# Altium schematic sheet （8）Power.Sch
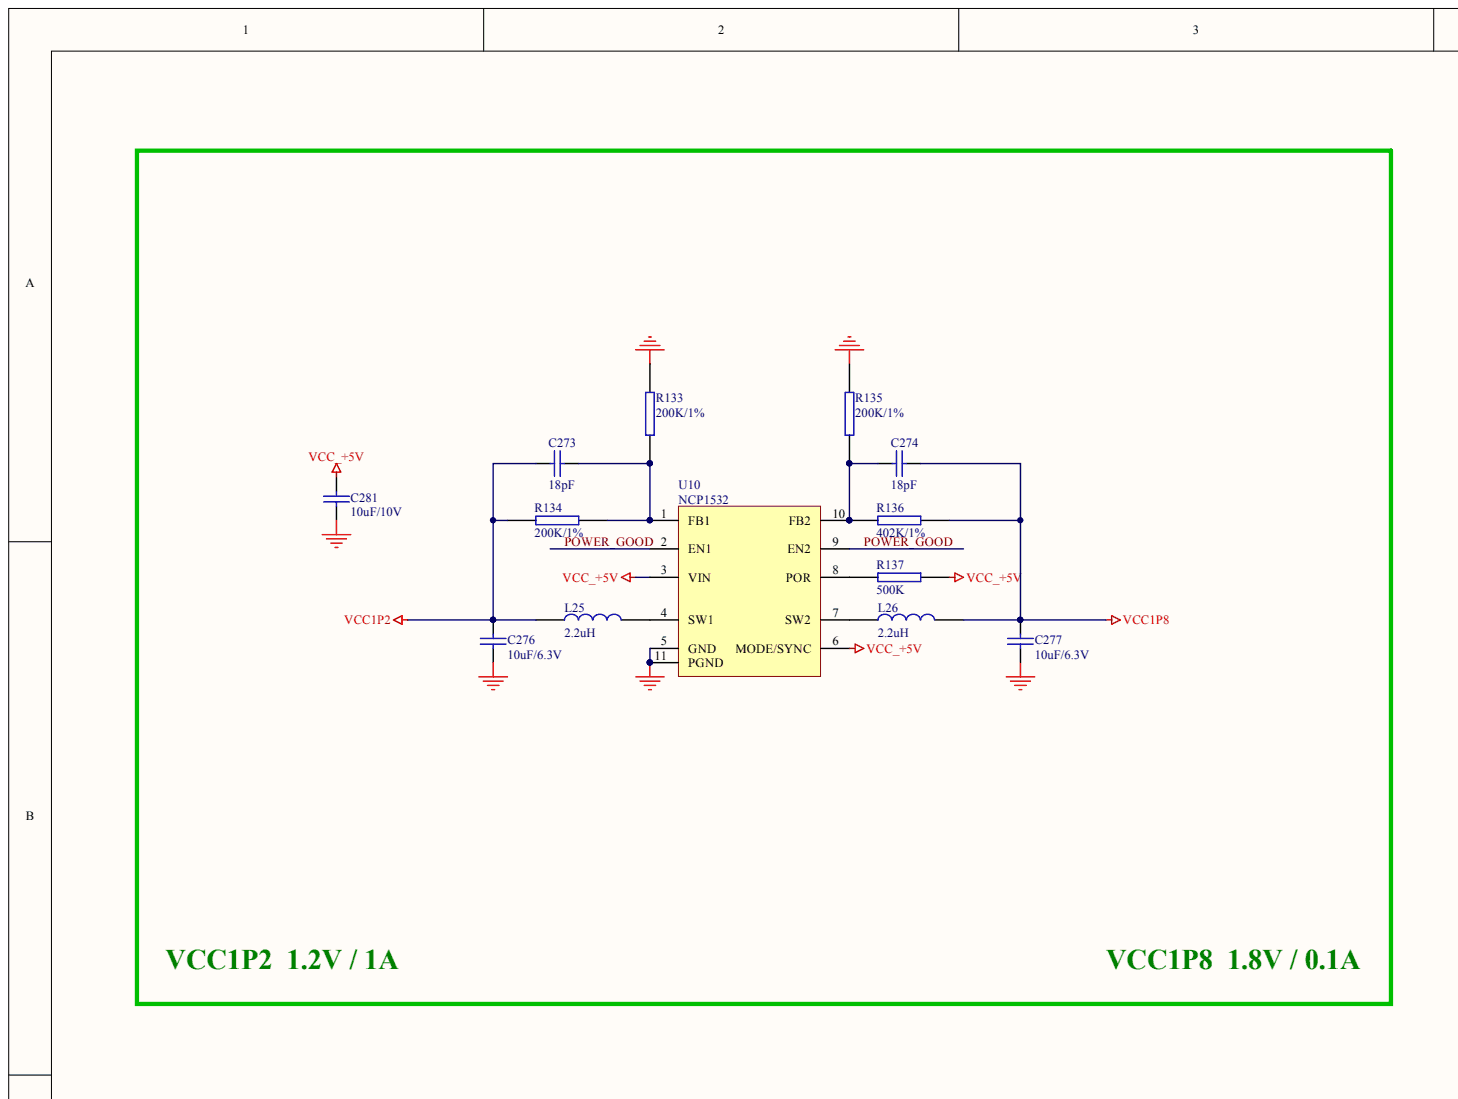
[diagram p0: （8）Power.Sch, top left]
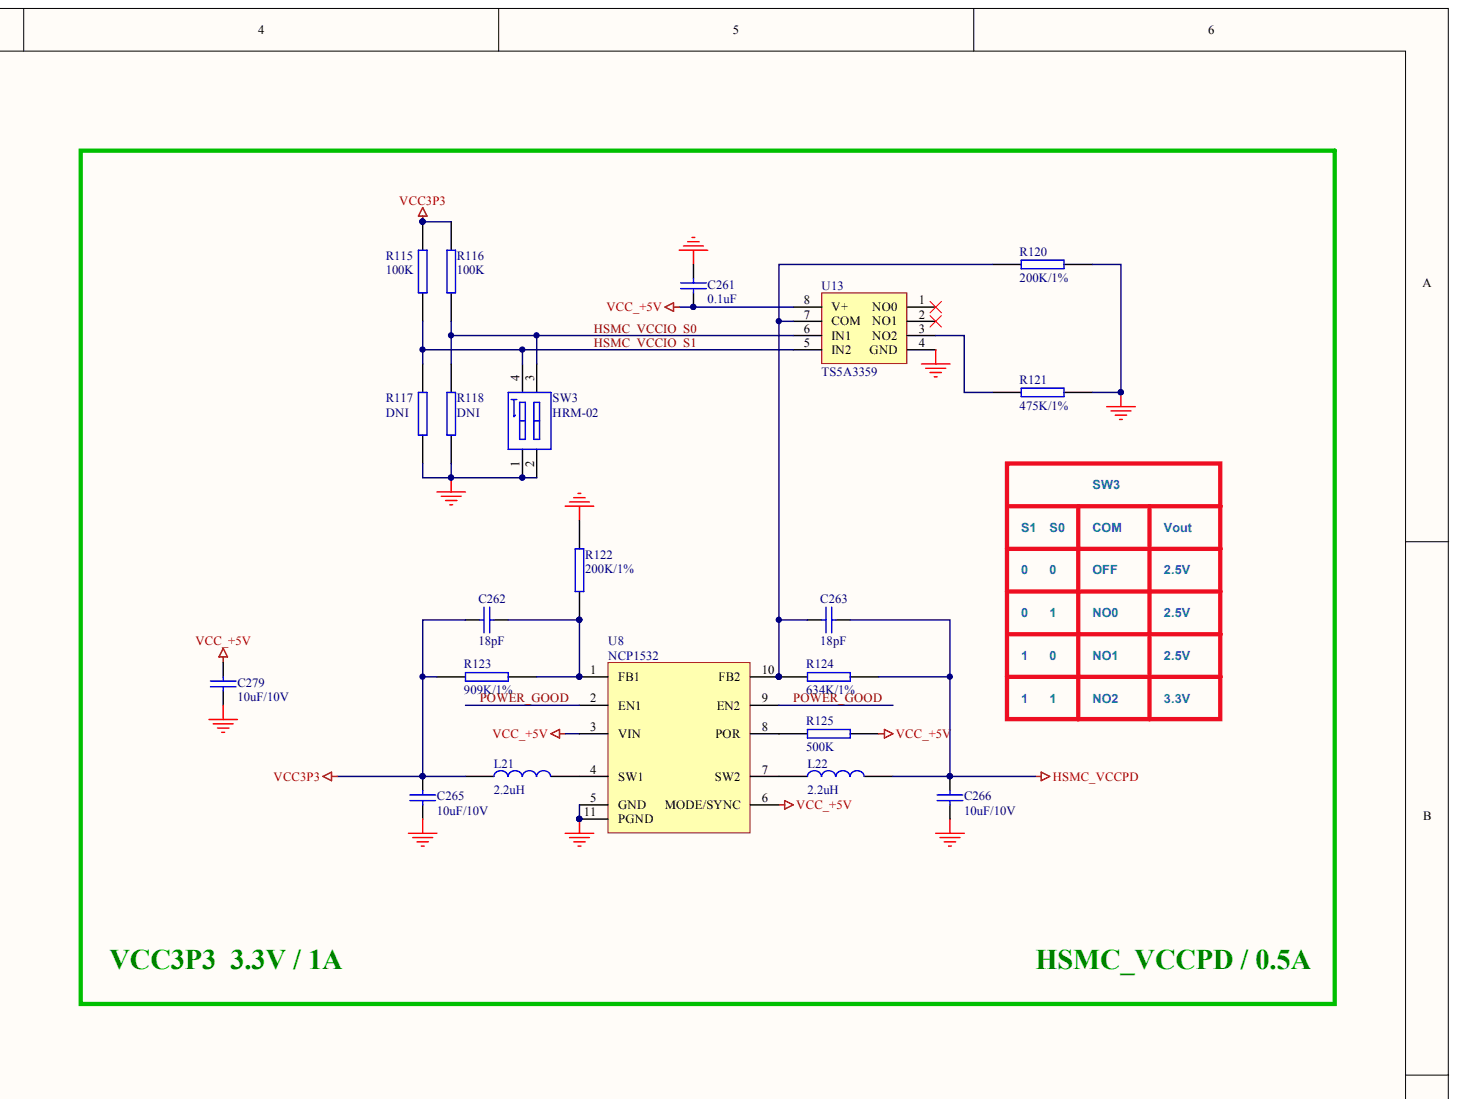
[diagram p1: （8）Power.Sch, top right]
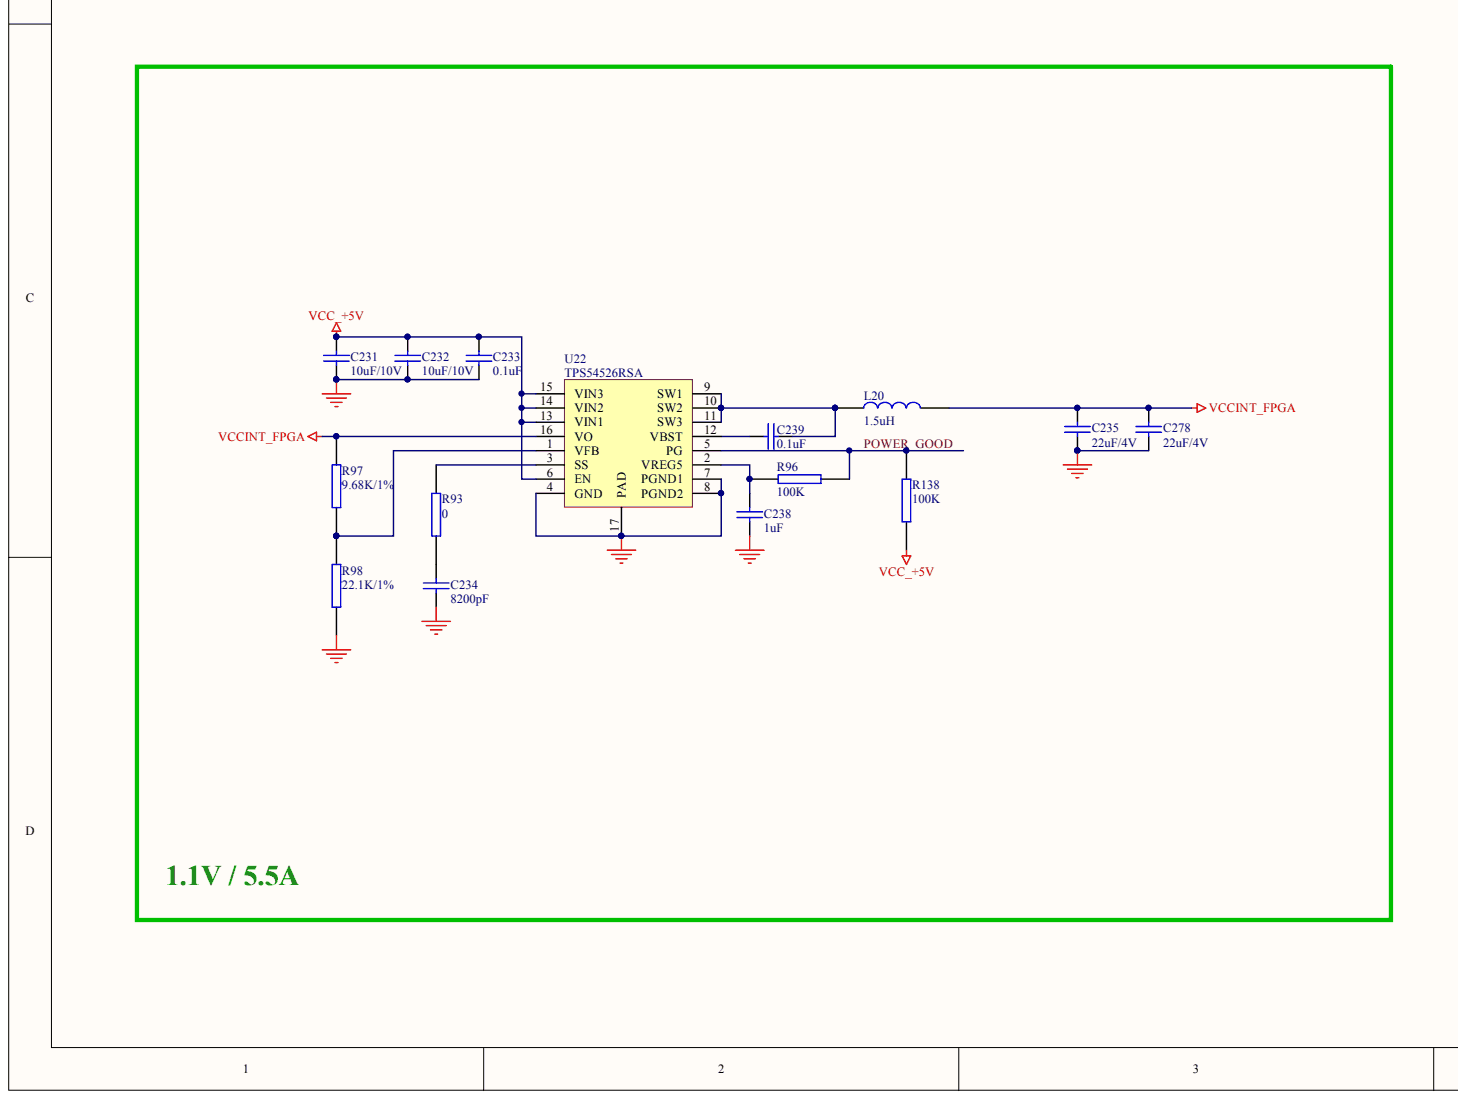
[diagram p2: （8）Power.Sch, bottom left]
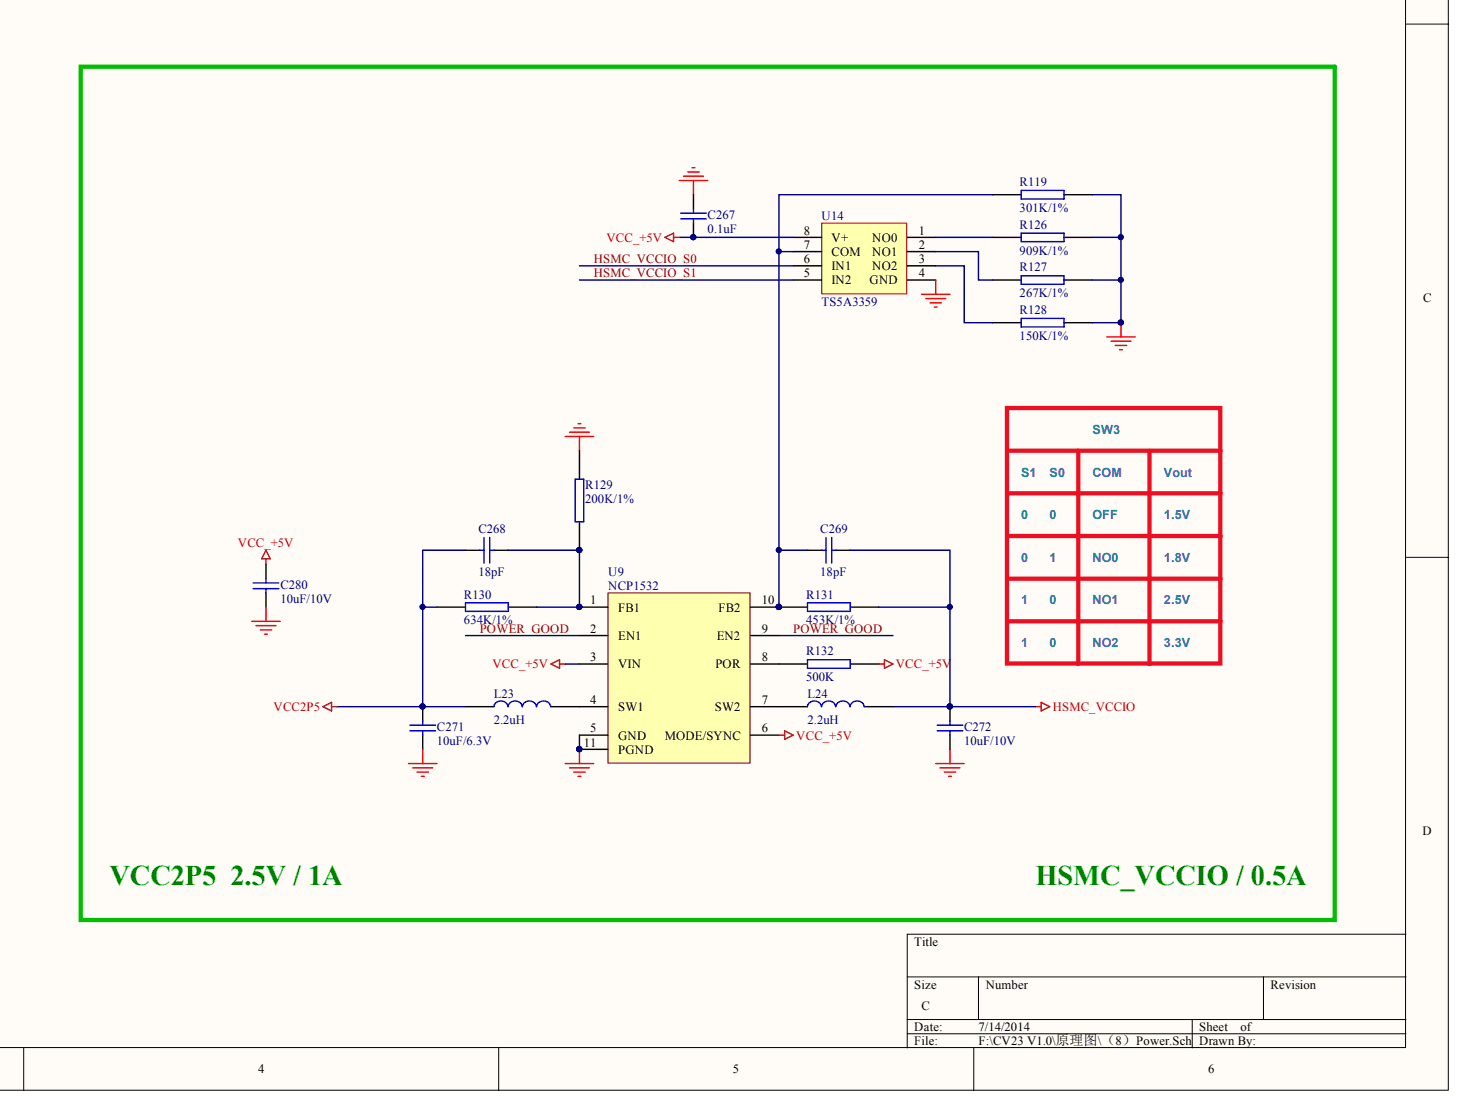
[diagram p3: （8）Power.Sch, bottom right]

## Parts
C231  10uF/10V
C232  10uF/10V
C233  0.1uF
C234  8200pF
C235  22uF/4V
C238  1uF
C239  0.1uF
C261  0.1uF
C262  18pF
C263  18pF
C265  10uF/10V
C266  10uF/10V
C267  0.1uF
C268  18pF
C269  18pF
C271  10uF/6.3V
C272  10uF/10V
C273  18pF
C274  18pF
C276  10uF/6.3V
C277  10uF/6.3V
C278  22uF/4V
C279  10uF/10V
C280  10uF/10V
C281  10uF/10V
L20  1.5uH
L21  2.2uH
L22  2.2uH
L23  2.2uH
L24  2.2uH
L25  2.2uH
L26  2.2uH
R93  0
R96  100K
R97  9.68K/1%
R98  22.1K/1%
R115  100K
R116  100K
R117  DNI
R118  DNI
R119  301K/1%
R120  200K/1%
R121  475K/1%
R122  200K/1%
R123  909K/1%
R124  634K/1%
R125  500K
R126  909K/1%
R127  267K/1%
R128  150K/1%
R129  200K/1%
R130  634K/1%
R131  453K/1%
R132  500K
R133  200K/1%
R134  200K/1%
R135  200K/1%
R136  402K/1%
R137  500K
R138  100K
SW3  HRM-02
U8  NCP1532
U9  NCP1532
U10  NCP1532
U13  TS5A3359
U14  TS5A3359
U22  TPS54526RSA

## Nets
GND: C231.2 C232.2 C233.1 C234.1 C235.2 C238.2 C261.1 C265.2 C266.2 C267.1 C271.2 C272.2 C276.2 C277.2 C278.2 C279.2 C280.2 C281.2 R98.1 R117.1 R118.1 R119.1 R120.1 R121.1 R122.2 R126.1 R127.1 R128.1 R129.2 R133.2 R135.2 SW3.1 SW3.2 U8.5(GND) U8.11(PGND) U9.5(GND) U9.11(PGND) U10.5(GND) U10.11(PGND) U13.4(GND) U14.4(GND) U22.4(GND) U22.7(PGND1) U22.8(PGND2) U22.17(PAD)
HSMC_VCCIO: C269.2 C272.1 L24.2 R131.1
HSMC_VCCPD: C263.2 C266.1 L22.2 R124.1
VCC1P2: C273.1 C276.1 L25.1 R134.2
VCC1P8: C274.2 C277.1 L26.2 R136.1
VCC2P5: C268.2 C271.1 L23.1 R130.2
VCC3P3: C262.2 C265.1 L21.1 R115.2 R116.2 R123.2
VCC_+5V: C231.1 C232.1 C233.2 C261.2 C267.2 C279.1 C280.1 C281.1 R125.1 R132.1 R137.1 R138.1 U8.3(VIN) U8.6(MODE/SYNC) U9.3(VIN) U9.6(MODE/SYNC) U10.3(VIN) U10.6(MODE/SYNC) U13.8(V+) U14.8(V+) U22.6(EN) U22.13(VIN1) U22.14(VIN2) U22.15(VIN3)
VCCINT_FPGA: C235.1 C278.1 L20.2 R97.2 U22.16(VO)
HSMC_VCCIO_S0: R116.1 R118.2 SW3.3 U13.6(IN1) U14.6(IN1)
HSMC_VCCIO_S1: R115.1 R117.2 SW3.4 U13.5(IN2) U14.5(IN2)
POWER_GOOD: R96.2 R138.2 U8.2(EN1) U8.9(EN2) U9.2(EN1) U9.9(EN2) U10.2(EN1) U10.9(EN2) U22.5(PG)
NetC234_2: C234.2 R93.2
NetC238_1: C238.1 R96.1 U22.2(VREG5)
NetC239_1: C239.1 U22.12(VBST)
NetC239_2: C239.2 L20.1 U22.9(SW1) U22.10(SW2) U22.11(SW3)
NetC262_1: C262.1 R122.1 R123.1 U8.1(FB1)
NetC263_1: C263.1 R120.2 R124.2 U8.10(FB2) U13.7(COM)
NetC268_1: C268.1 R129.1 R130.1 U9.1(FB1)
NetC269_1: C269.1 R119.2 R131.2 U9.10(FB2) U14.7(COM)
NetC273_2: C273.2 R133.1 R134.1 U10.1(FB1)
NetC274_1: C274.1 R135.1 R136.2 U10.10(FB2)
NetL21_2: L21.2 U8.4(SW1)
NetL22_1: L22.1 U8.7(SW2)
NetL23_2: L23.2 U9.4(SW1)
NetL24_1: L24.1 U9.7(SW2)
NetL25_2: L25.2 U10.4(SW1)
NetL26_1: L26.1 U10.7(SW2)
NetR93_1: R93.1 U22.3(SS)
NetR97_1: R97.1 R98.2 U22.1(VFB)
NetR121_2: R121.2 U13.3(NO2)
NetR125_2: R125.2 U8.8(POR)
NetR126_2: R126.2 U14.1(NO0)
NetR127_2: R127.2 U14.2(NO1)
NetR128_2: R128.2 U14.3(NO2)
NetR132_2: R132.2 U9.8(POR)
NetR137_2: R137.2 U10.8(POR)

Not connected: U13 1(NO0) 2(NO1)
